# Altium schematic sheet MCU-SCH.SchDoc
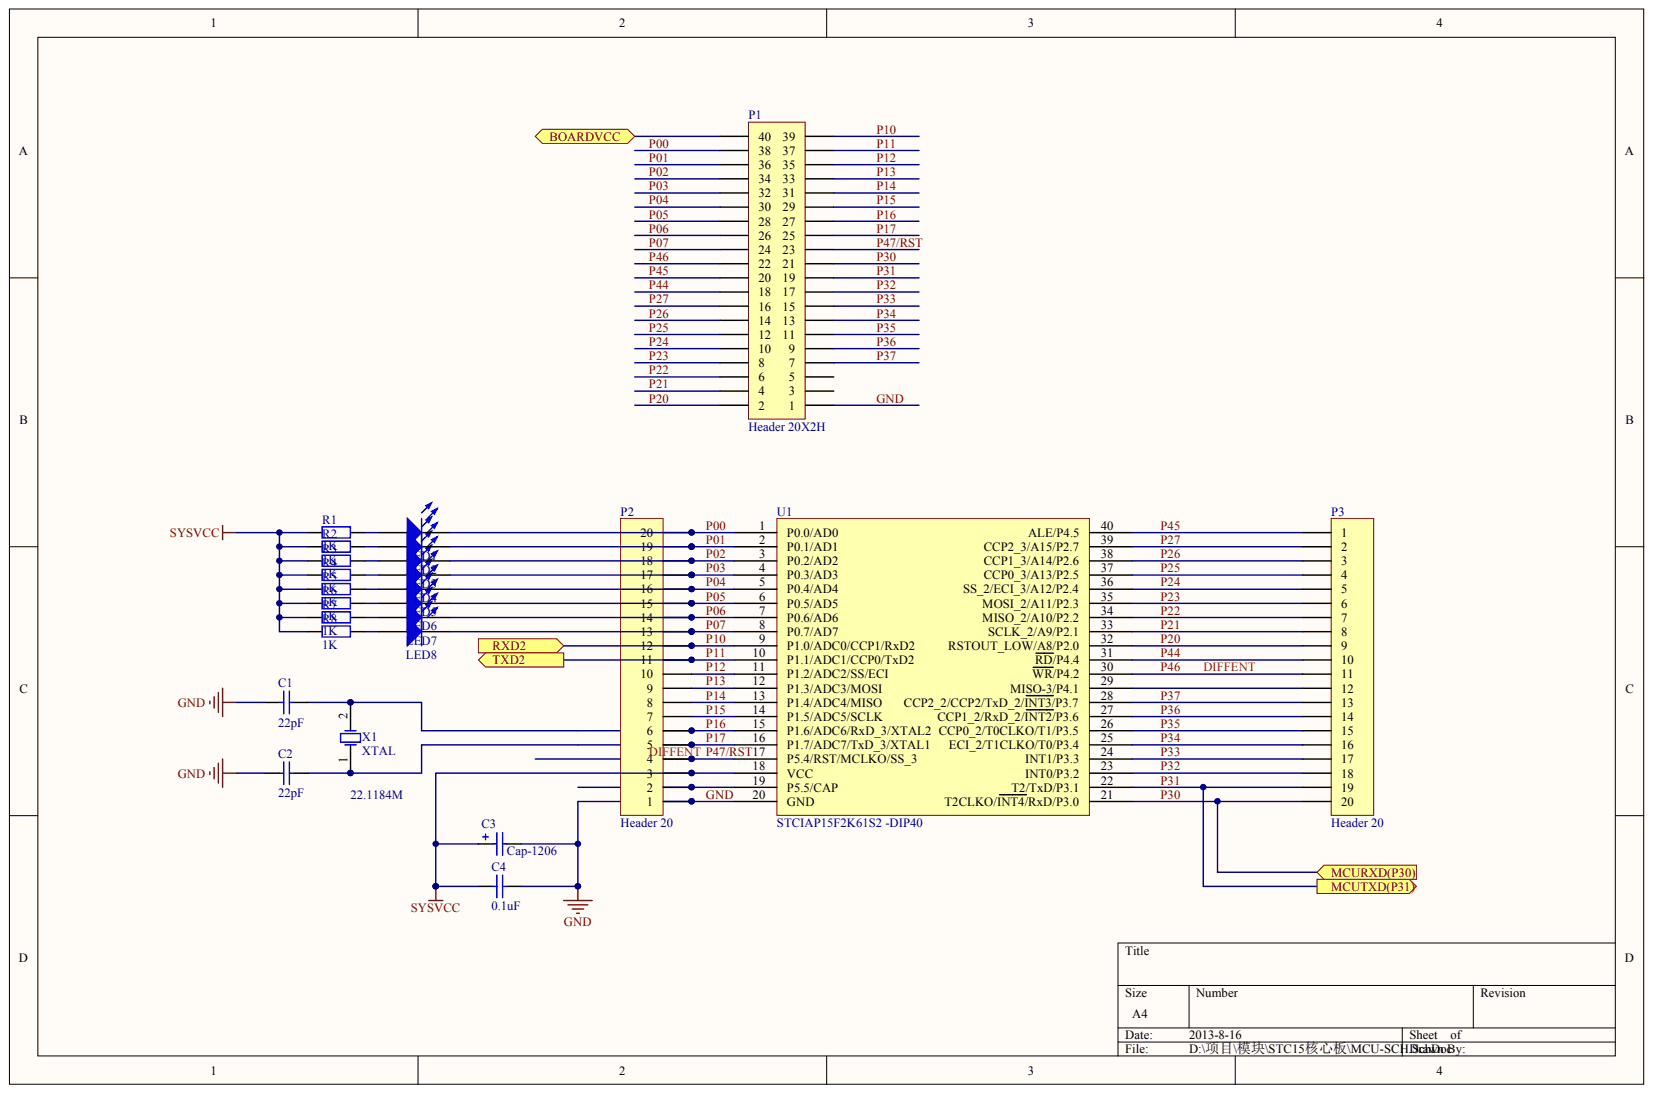
[diagram p0: MCU-SCH.SchDoc]

## Parts
C1  Cap 0805  (value not drawn)
C2  Cap 0805  (value not drawn)
C3  Cap-1206
C4  Cap 0805  (value not drawn)
LED1  LED_0805  (value not drawn)
LED2  LED_0805  (value not drawn)
LED3  LED_0805  (value not drawn)
LED4  LED_0805  (value not drawn)
LED5  LED_0805  (value not drawn)
LED6  LED_0805  (value not drawn)
LED7  LED_0805  (value not drawn)
LED8  LED_0805  (value not drawn)
P1  Header 20X2H
P2  Header 20
P3  Header 20
R1  RES  (value not drawn)
R2  RES  (value not drawn)
R3  RES  (value not drawn)
R4  RES  (value not drawn)
R5  RES  (value not drawn)
R6  RES  (value not drawn)
R7  RES  (value not drawn)
R8  RES  (value not drawn)
U1  STCIAP15F2K61S2 -DIP40
X1  XTAL

## Ports
BOARDVCC, MCURXD(P30), MCUTXD(P31), RXD2, TXD2

## Nets
SYSVCC: C3.1 C4.1 P2.3 R1.2 R2.2 R3.2 R4.2 R5.2 R6.2 R7.2 R8.2 U1.18(VCC)
BOARDVCC: P1.40 ; port BOARDVCC
GND: C1.1 C2.1 C3.2 C4.2 P1.1 P2.1 U1.20(GND)
P00: LED1.2 P1.38 P2.20 U1.1(P0.0/AD0)
P01: LED2.2 P1.36 P2.19 U1.2(P0.1/AD1)
P02: LED3.2 P1.34 P2.18 U1.3(P0.2/AD2)
P03: LED4.2 P1.32 P2.17 U1.4(P0.3/AD3)
P04: LED5.2 P1.30 P2.16 U1.5(P0.4/AD4)
P05: LED6.2 P1.28 P2.15 U1.6(P0.5/AD5)
P06: LED7.2 P1.26 P2.14 U1.7(P0.6/AD6)
P07: LED8.2 P1.24 P2.13 U1.8(P0.7/AD7)
P10: P1.39 P2.12 U1.9(P1.0/ADC0/CCP1/RxD2) ; port RXD2
P11: P1.37 P2.11 U1.10(P1.1/ADC1/CCP0/TxD2) ; port TXD2
P12: P1.35 P2.10 U1.11(P1.2/ADC2/SS/ECI)
P13: P1.33 P2.9 U1.12(P1.3/ADC3/MOSI)
P14: P1.31 P2.8 U1.13(P1.4/ADC4/MISO)
P15: P1.29 P2.7 U1.14(P1.5/ADC5/SCLK)
P16: C1.2 P1.27 P2.6 U1.15(P1.6/ADC6/RxD_3/XTAL2) X1.2
P17: C2.2 P1.25 P2.5 U1.16(P1.7/ADC7/TxD_3/XTAL1) X1.1
P20: P1.2 P3.9 U1.32(RSTOUT_LOW/A8/P2.0)
P21: P1.4 P3.8 U1.33(SCLK_2/A9/P2.1)
P22: P1.6 P3.7 U1.34(MISO_2/A10/P2.2)
P23: P1.8 P3.6 U1.35(MOSI_2/A11/P2.3)
P24: P1.10 P3.5 U1.36(SS_2/ECI_3/A12/P2.4)
P25: P1.12 P3.4 U1.37(CCP0_3/A13/P2.5)
P26: P1.14 P3.3 U1.38(CCP1_3/A14/P2.6)
P27: P1.16 P3.2 U1.39(CCP2_3/A15/P2.7)
P30: P1.21 P3.20 U1.21(T2CLKO/INT4/RxD/P3.0) ; port MCURXD(P30)
P31: P1.19 P3.19 U1.22(T2/TxD/P3.1) ; port MCUTXD(P31)
P32: P1.17 P3.18 U1.23(INT0/P3.2)
P33: P1.15 P3.17 U1.24(INT1/P3.3)
P34: P1.13 P3.16 U1.25(ECI_2/T1CLKO/T0/P3.4)
P35: P1.11 P3.15 U1.26(CCP0_2/T0CLKO/T1/P3.5)
P36: P1.9 P3.14 U1.27(CCP1_2/RxD_2/INT2/P3.6)
P37: P1.7 P3.13 U1.28(CCP2_2/CCP2/TxD_2/INT3/P3.7)
P44: P1.18 P3.10 U1.31(RD/P4.4)
P45: P1.20 P3.1 U1.40(ALE/P4.5)
P46: P1.22 P3.11 U1.30(WR/P4.2)
P47/RST: P1.23 P2.4 U1.17(P5.4/RST/MCLKO/SS_3)
NetLED1_1: LED1.1 R1.1
NetLED2_1: LED2.1 R2.1
NetLED3_1: LED3.1 R3.1
NetLED4_1: LED4.1 R4.1
NetLED5_1: LED5.1 R5.1
NetLED6_1: LED6.1 R6.1
NetLED7_1: LED7.1 R7.1
NetLED8_1: LED8.1 R8.1
NetP2_2: P2.2 U1.19(P5.5/CAP)
NetP3_12: P3.12 U1.29(MISO-3/P4.1)

Not connected: P1 3 5

## Library data of the parts
C1-C4 LED1-LED8 P1-P3 R1-R8 U1 X1 = (no symbol name)
  C1 C2: : 22pF
  C4: : 0.1uF
  P1-P3: LatestRevisionDate: 17-Jul-2002; LatestRevisionNote: Re-released for DXP Platform.; Publisher: Altium Limited
  R1-R8: : 1K
  X1: : 22.1184M
